# Altium schematic sheet esp32.SchDoc
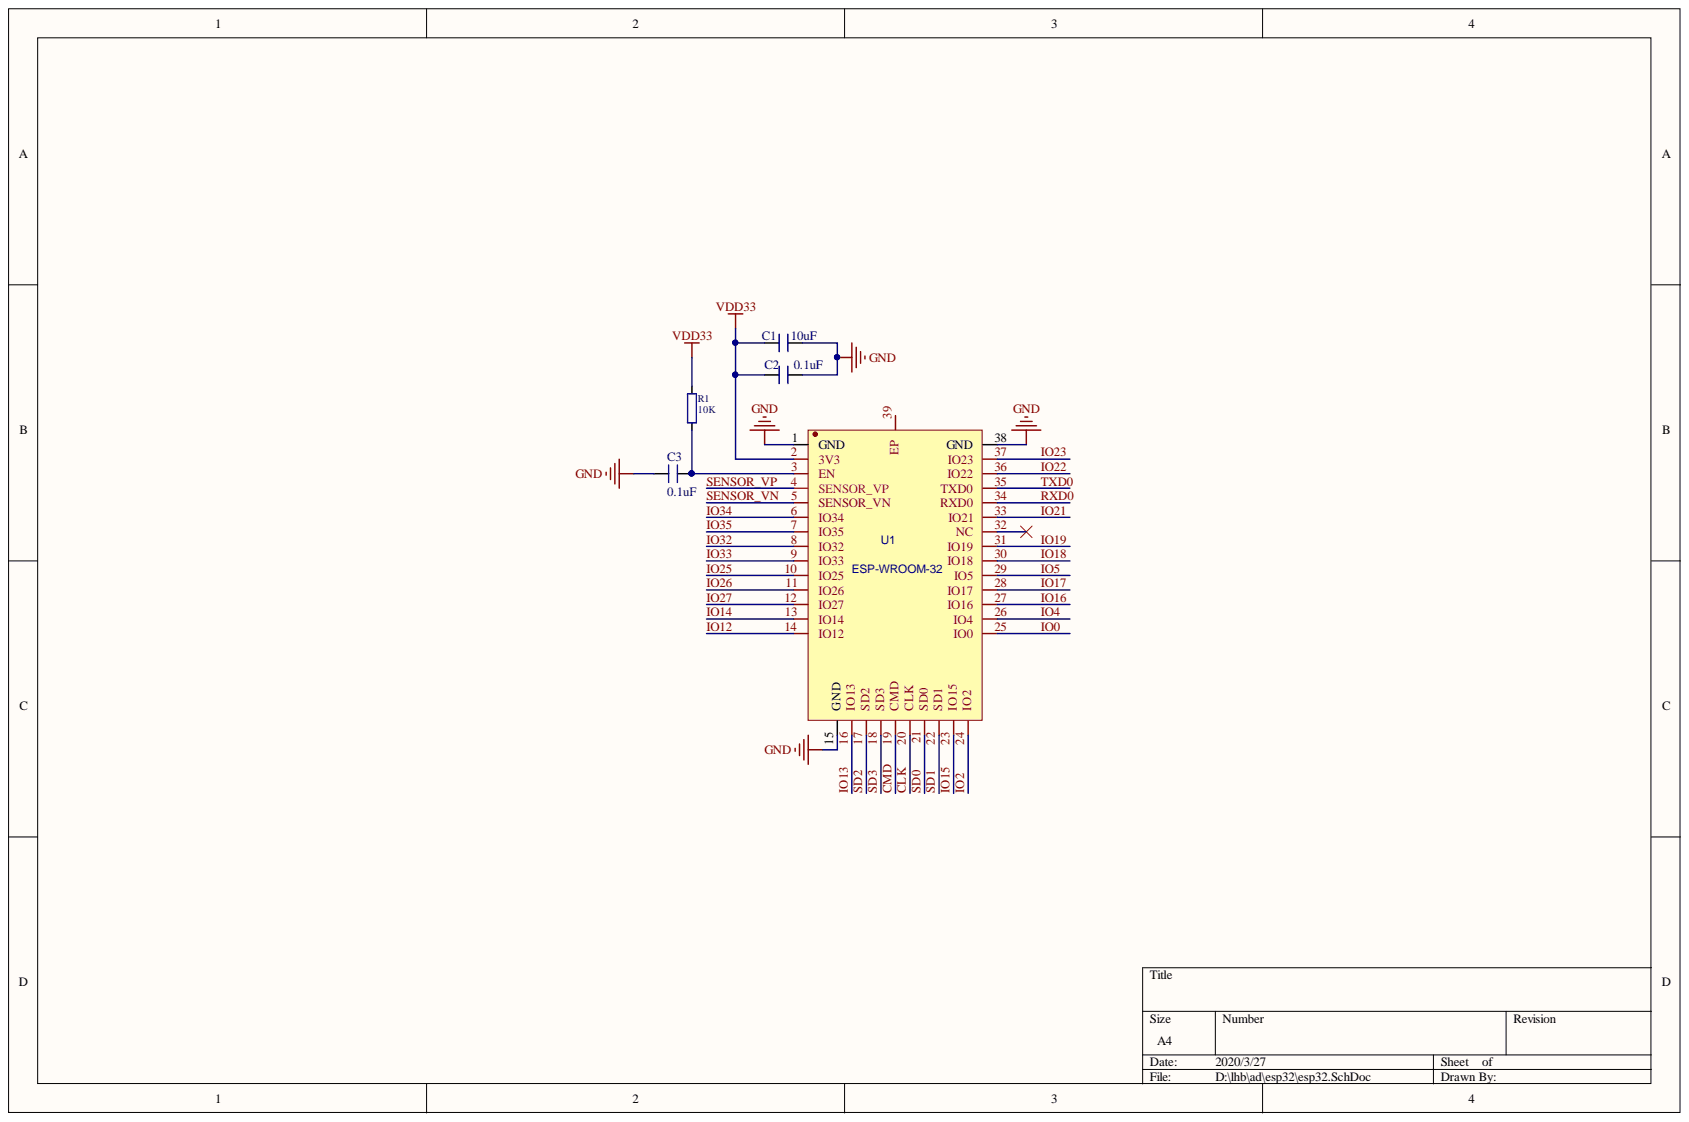
[diagram p0: esp32.SchDoc]

## Parts
C1  10uF  [C0603]
C2  0.1uF  [C0603]
C3  0.1uF  [C0603]
R1  10K  [R0603]
U1  ESP-WROOM-32  [ESP-WROOM-32]

## Nets
GND: C1.1 C2.2 C3.1 U1.1(GND) U1.15(GND) U1.38(GND)
VDD33: C1.2 C2.1 R1.2 U1.2(3V3)
CLK: U1.20(CLK)
CMD: U1.19(CMD)
IO0: U1.25(IO0)
IO2: U1.24(IO2)
IO4: U1.26(IO4)
IO5: U1.29(IO5)
IO12: U1.14(IO12)
IO13: U1.16(IO13)
IO14: U1.13(IO14)
IO15: U1.23(IO15)
IO16: U1.27(IO16)
IO17: U1.28(IO17)
IO18: U1.30(IO18)
IO19: U1.31(IO19)
IO21: U1.33(IO21)
IO22: U1.36(IO22)
IO23: U1.37(IO23)
IO25: U1.10(IO25)
IO26: U1.11(IO26)
IO27: U1.12(IO27)
IO32: U1.8(IO32)
IO33: U1.9(IO33)
IO34: U1.6(IO34)
IO35: U1.7(IO35)
RXD0: U1.34(RXD0)
SD0: U1.21(SD0)
SD1: U1.22(SD1)
SD2: U1.17(SD2)
SD3: U1.18(SD3)
SENSOR_VN: U1.5(SENSOR_VN)
SENSOR_VP: U1.4(SENSOR_VP)
TXD0: U1.35(TXD0)
NetC3_2: C3.2 R1.1 U1.3(EN)

Not connected: U1 32(NC) 39(EP)

## Library data of the parts
C1-C3 = C0603: Component Kind: Standard; Library Name: esp32.SCHLIB
R1 = RES: Component Kind: Standard; Library Name: esp32.SCHLIB
U1 = ESP-WROOM-32: BOM_Manufacturer: ESPRESSIF; BOM_Manufacturer Part: ESP-WROOM-32; BOM_Supplier: LCSC; BOM_Supplier Part: C95209; Component Kind: Standard; Library Name: esp32.SCHLIB; spicePre: U; spiceSymbolName: ESP-WROOM-32
